# Altium schematic sheet Connectors_V2_1.SchDoc(Connectors_V2_1)
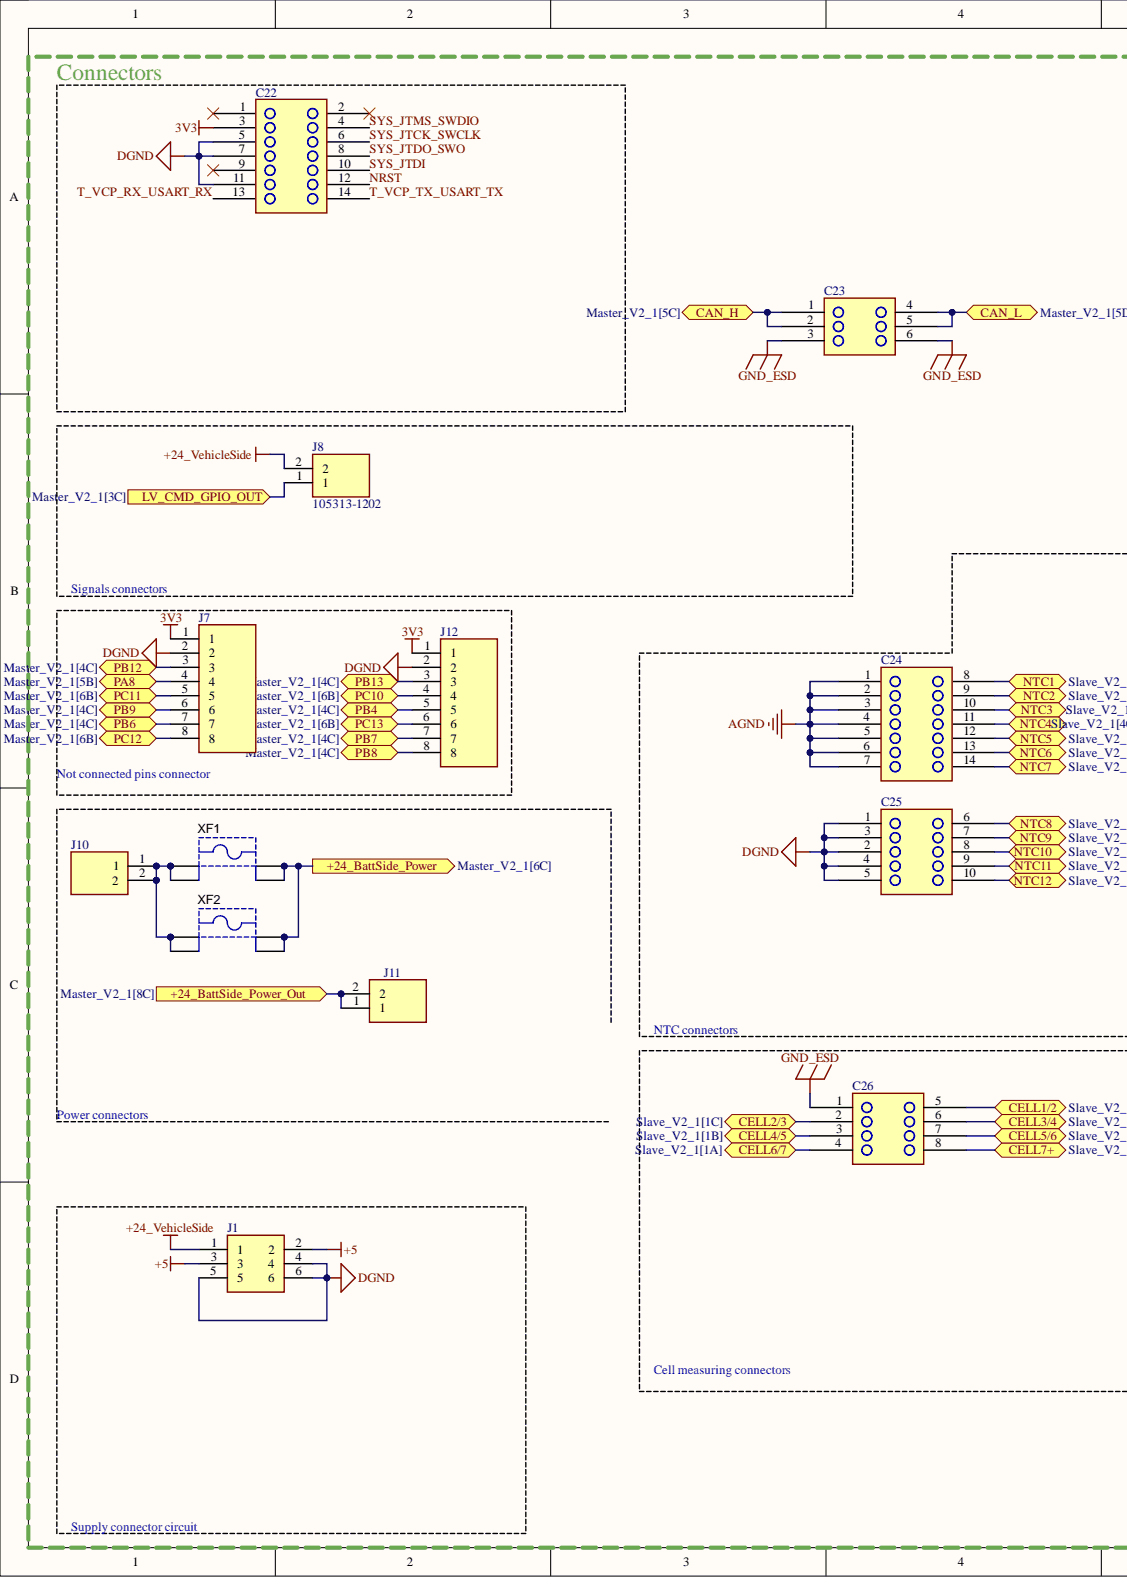
[diagram p0: Connectors_V2_1.SchDoc, left]
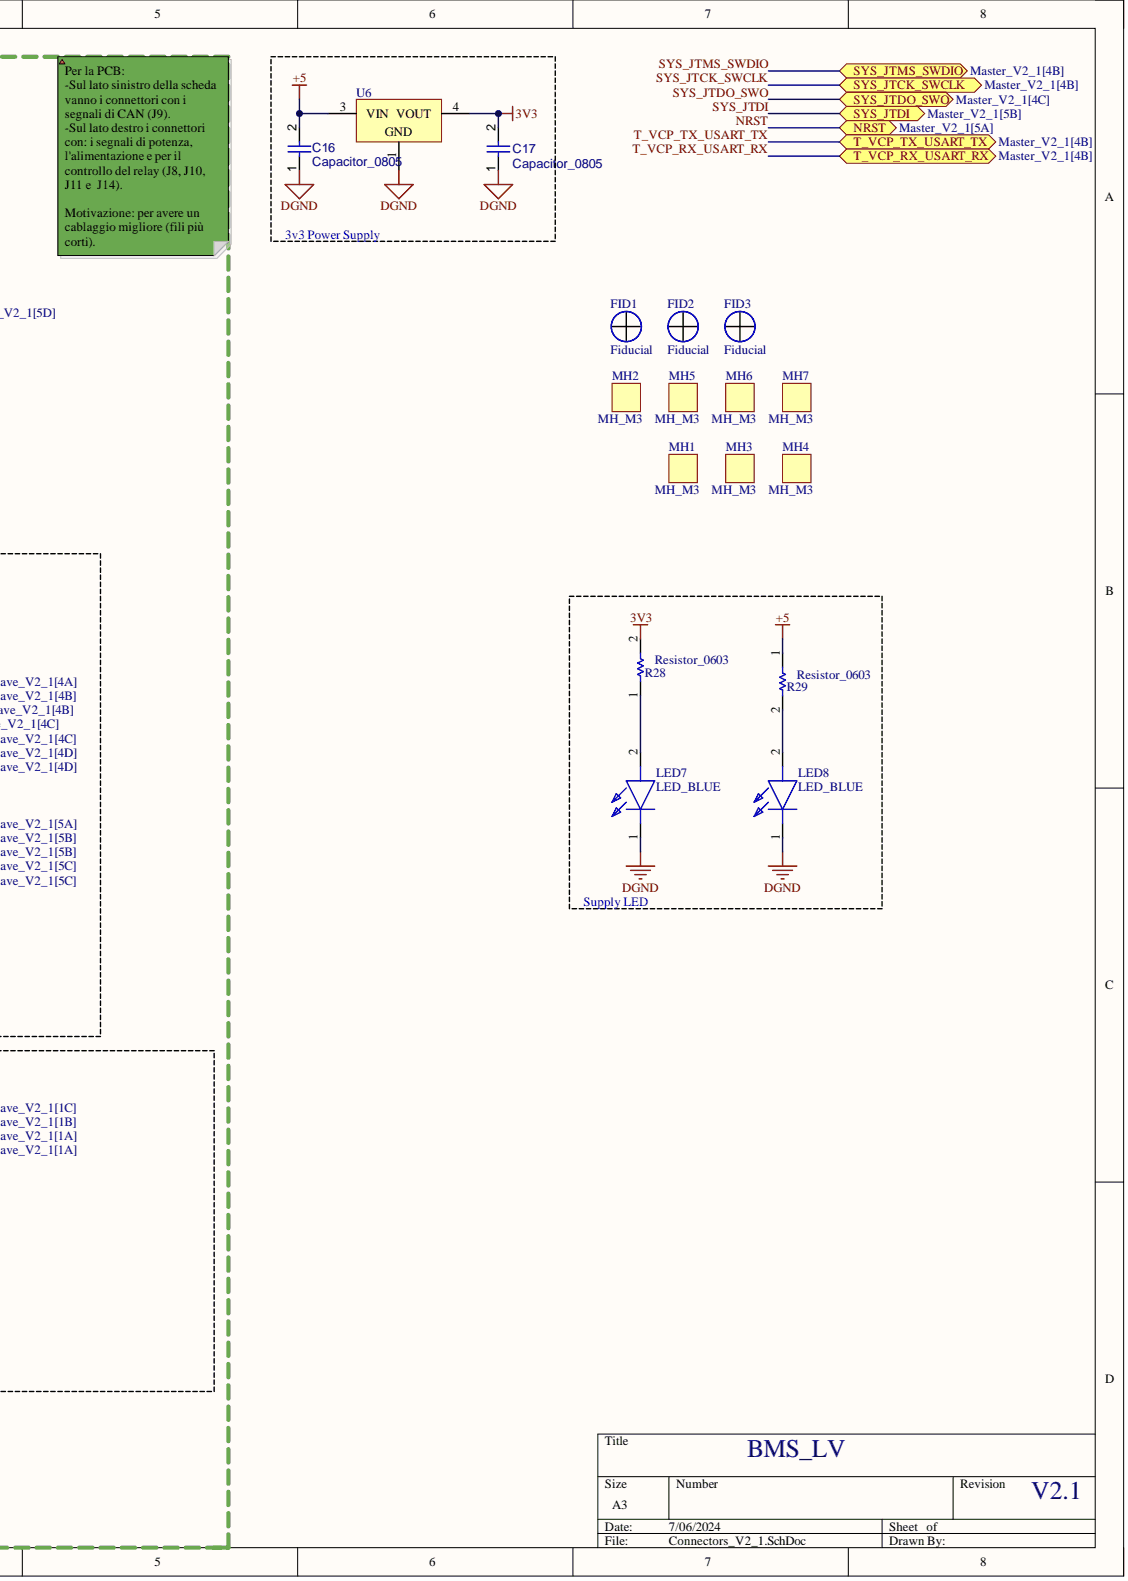
[diagram p1: Connectors_V2_1.SchDoc, right]

## Parts
C16  Capacitor_0805
C17  Capacitor_0805
C22  Samtec Header, 14 Pin, 1.27mm pitch  (value not drawn)
C23  NanoFit 6 Pin, Right Angle, Gold Plating  (value not drawn)
C24  NanoFit 14 Pin, Vertical,  Gold Plating  (value not drawn)
C25  105310-1210  (value not drawn)
C26  NanoFit 8 Pin, Vertical, Gold Plating105310-1208  (value not drawn)
FID1  Fiducial
FID2  Fiducial
FID3  Fiducial
J1  Molex Milli-Grid Series  (value not drawn)
J7  Headers & Wire Housings 8CKT VERT SR CON  (value not drawn)
J8  105313-1202
J10  200241-1222  (value not drawn)
J11  200241-1222  (value not drawn)
J12  Headers & Wire Housings 8CKT VERT SR CON  (value not drawn)
LED7  LED_BLUE
LED8  LED_BLUE
MH1  MH_M3
MH2  MH_M3
MH3  MH_M3
MH4  MH_M3
MH5  MH_M3
MH6  MH_M3
MH7  MH_M3
R28  Resistor_0603
R29  Resistor_0603
U6  LD1117DT33CTR  (value not drawn)
XF1  FUSE HOLDER, PCB MOUNT, 500VAC, 20A, ROHS  (value not drawn)
XF2  3557-2  (value not drawn)

## Ports
+24_BattSide_Power, +24_BattSide_Power_Out, CAN_H, CAN_L, CELL1/2, CELL2/3, CELL3/4, CELL4/5, CELL5/6, CELL6/7, CELL7+, LV_CMD_GPIO_OUT, NRST, NTC1, NTC2, NTC3, NTC4, NTC5, NTC6, NTC7, NTC8, NTC9, NTC10, NTC11, NTC12, PA8, PB4, PB6, PB7, PB8, PB9, PB12, PB13, PC10, PC11, PC12, PC13, SYS_JTCK_SWCLK, SYS_JTDI, SYS_JTDO_SWO, SYS_JTMS_SWDIO, T_VCP_RX_USART_RX, T_VCP_TX_USART_TX

## Nets
3V3: C17.2 C22.3 J7.1 J12.1 R28.2 U6.4
+5: C16.2 J1.2 J1.3 R29.1 U6.3
+24_VehicleSide: J1.1 J8.2
AGND: C24.1 C24.2 C24.3 C24.4 C24.5 C24.6 C24.7
DGND: C16.1 C17.1 C22.5 C22.7 C22.11 C25.1 C25.2 C25.3 C25.4 C25.5 J1.4 J1.5 J1.6 J7.2 J12.2 LED7.1 LED8.1 U6.1
GND_ESD: C23.3 C23.6 C26.1
+24_BattSide_Power: XF1.3 XF1.4 XF2.3 XF2.4 ; port +24_BattSide_Power
+24_BattSide_Power_Out: J11.1 J11.2 ; port +24_BattSide_Power_Out
CAN_H: C23.1 C23.2 ; port CAN_H
CAN_L: C23.4 C23.5 ; port CAN_L
CELL1/2: C26.5 ; port CELL1/2
CELL2/3: C26.2 ; port CELL2/3
CELL3/4: C26.6 ; port CELL3/4
CELL4/5: C26.3 ; port CELL4/5
CELL5/6: C26.7 ; port CELL5/6
CELL6/7: C26.4 ; port CELL6/7
CELL7+: C26.8 ; port CELL7+
LV_CMD_GPIO_OUT: J8.1 ; port LV_CMD_GPIO_OUT
NRST: C22.12 ; port NRST
NTC1: C24.8 ; port NTC1
NTC2: C24.9 ; port NTC2
NTC3: C24.10 ; port NTC3
NTC4: C24.11 ; port NTC4
NTC5: C24.12 ; port NTC5
NTC6: C24.13 ; port NTC6
NTC7: C24.14 ; port NTC7
NTC8: C25.6 ; port NTC8
NTC9: C25.7 ; port NTC9
NTC10: C25.8 ; port NTC10
NTC11: C25.9 ; port NTC11
NTC12: C25.10 ; port NTC12
PA8: J7.4 ; port PA8
PB4: J12.5 ; port PB4
PB6: J7.7 ; port PB6
PB7: J12.7 ; port PB7
PB8: J12.8 ; port PB8
PB9: J7.6 ; port PB9
PB12: J7.3 ; port PB12
PB13: J12.3 ; port PB13
PC10: J12.4 ; port PC10
PC11: J7.5 ; port PC11
PC12: J7.8 ; port PC12
PC13: J12.6 ; port PC13
SYS_JTCK_SWCLK: C22.6 ; port SYS_JTCK_SWCLK
SYS_JTDI: C22.10 ; port SYS_JTDI
SYS_JTDO_SWO: C22.8 ; port SYS_JTDO_SWO
SYS_JTMS_SWDIO: C22.4 ; port SYS_JTMS_SWDIO
T_VCP_RX_USART_RX: C22.13 ; port T_VCP_RX_USART_RX
T_VCP_TX_USART_TX: C22.14 ; port T_VCP_TX_USART_TX
NetJ10_1: J10.1 J10.2 XF1.1 XF1.2 XF2.1 XF2.2
NetLED7_2: LED7.2 R28.1
NetLED8_2: LED8.2 R29.2

Not connected: C22 1 2 9

## Library data of the parts
C16 C17 = CMP-001-00085-2: Footprint: 0805; Tech: SMD; Component Kind: Standard; ComponentLink1Description: Website; Package: 0805; Library Name: EMEA- Squadra Corse PoliTO-  Politecnico di Torino
C22 = CMP-00004-3: FTSH-107-01-L-DV-K-A; Component Kind: Standard; Library Name: EMEA- Squadra Corse PoliTO-  Politecnico di Torino
C23 = CMP-000003-3: 105314-1206; Component Kind: Standard; Library Name: EMEA- Squadra Corse PoliTO-  Politecnico di Torino
C24 = CMP-000001-6: 105310-1214; Component Kind: Standard; Library Name: EMEA- Squadra Corse PoliTO-  Politecnico di Torino
C25 = CMP-00003-4: NanoFit 10 Pin, Vertical, Gold Plating; Component Kind: Standard; Library Name: EMEA- Squadra Corse PoliTO-  Politecnico di Torino
C26 = CMP-00005-3: 105310-1208; Component Kind: Standard; Library Name: EMEA- Squadra Corse PoliTO-  Politecnico di Torino
FID1-FID3 = CMP-00028-00007-10: Component Kind: Standard; Library Name: EMEA- Squadra Corse PoliTO-  Politecnico di Torino
J1 = CMP-040-000015-3: 7910779107-7002; Tech: THT; Component Kind: Standard; Library Name: EMEA- Squadra Corse PoliTO-  Politecnico di Torino
J7 J12 = CMP-104-000000-2: 90147-1108; Manufacturer_Name: Molex; Geometry.Height: 8.7mm; Component Kind: Standard; Datasheet Link: https://www.molex.com/pdm_docs/sd/901471102_sd.pdf; Design Item ID: 90147-1108; Manufacturer_Part_Number: 90147-1108; Mouser Part Number: 538-90147-1108; Mouser Price/Stock: https://www.mouser.co.uk/ProductDetail/Molex/90147-1108?qs=QESXi79X%252BKqiwXr7kUdEeg%3D%3D; Source: 90147-1108.SchLib; Library Name: EMEA- Squadra Corse PoliTO-  Politecnico di Torino
J8 = CMP-003-000024-1: Connector; Manufacturer_Name: Molex; Component Kind: Standard; Datasheet Link: http://www.molex.com/pdm_docs/sd/1053131202_sd.pdf; Design Item ID: 105313-1202; Manufacturer_Part_Number: 105313-1202; Mouser Part Number: 538-105313-1202; Mouser Price/Stock: https://www.mouser.co.uk/ProductDetail/Molex/105313-1202?qs=PqFvFmEiOr6Yr1uRJ10a3A%3D%3D; Source: SamacSys.SchLib; Library Name: EMEA- Squadra Corse PoliTO-  Politecnico di Torino
J10 = CMP-008-000005-2: Current: 23.5 A; Tech: THT; Component Kind: Standard; Description: 200241-1222; Library Name: EMEA- Squadra Corse PoliTO-  Politecnico di Torino
J11 = CMP-008-000005-2: MEGAFIT; Current: 23.5 A; Tech: THT; Component Kind: Standard; Library Name: EMEA- Squadra Corse PoliTO-  Politecnico di Torino
LED7 LED8 = CMP-031-000016-1: APT2012LVBC_D; Manufacturer_Name: Kingbright; Manufacturer_Part_Number: APT2012LVBC/D; Arrow Part Number: APT2012LVBC/D; Arrow Price/Stock: https://www.arrow.com/en/products/apt2012lvbcd/kingbright; Component Kind: Standard; Datasheet Link: https://componentsearchengine.com/Datasheets/1/APT2012LVBC_D.pdf; Design Item ID: APT2012LVBC_D; Height: 0.85mm; Mouser Part Number: 604-APT2012LVBCD; Mouser Price/Stock: https://www.mouser.co.uk/ProductDetail/Kingbright/APT2012LVBC-D?qs=6oMev5NRZMGErJYT6KZLXg%3D%3D; Source: SamacSys.SchLib; Library Name: EMEA- Squadra Corse PoliTO-  Politecnico di Torino
MH1-MH7 = CMP-00028-00008-3: Mountinh Hole M3, 3,5 mm hole, 6,5 mm external; Component Kind: Standard; Library Name: EMEA- Squadra Corse PoliTO-  Politecnico di Torino
R28 R29 = CMP-009-00179-4: CRCW0603300RFKEA; Tech: SMD; Component Kind: Standard; ComponentLink1Description: Website; Package: 0603; Library Name: EMEA- Squadra Corse PoliTO-  Politecnico di Torino
U6 = CMP-0244-00089-1: Fixed Low Drop Positive Voltage Regulator, 3.3V, 3-Pin DPAK, Tape and Reel; Component Kind: Standard; ComponentLink1Description: Manufacturer URL; ComponentLink1URL: http://www.st.com; ComponentLink2Description: Datasheet; ComponentLink2URL: http://www.st.com/internet/com/TECHNICAL_RESOURCES/TECHNICAL_LITERATURE/DATASHEET/CD00000544.pdf; DatasheetVersion: 1/11/2011; Library Name: Altium Content Vault; PackageDescription: 3-Pin Transistor Outline Package 2.28 mm Pitch, 9.7 mm Lead Span (DPAK); PackageReference: TO-252; PackageVersion: 2011
XF1 = CMP-2000-05461-1: Manufacturer: Keystone-Electronics; Current Rating: 30A; For Use With/Related Products: ATC,ATO257,MINI897; Fuse Size: 0.43"Lx0.15"Wx0.64"H(10.92mmx3.81mmx16.25mm); Fuse Type: Blade; Fuseholder Type: Block; Number of Circuits: 1; Voltage: 500V; Category: CircuitProtection; Component Kind: Standard; Contact Finish: TinNickel; Contact Material: Brass; Datasheet URL: http://datasheet.octopart.com/3557-2-Keystone-datasheet-15715937.pdf; Datasheet Version: Apr-13; Description: FUSE HOLDER, PCB MOUNT, 500VAC, 20A, ROHS; Family: Fuseholders; Library Name: Altium Content Vault; Manufacturer URL: http://www.keyelco.com/; Mounting Type: PCB; Orientation: Vertical; Series: -; Termination Style: PCPin
XF2 = CMP-2000-05461-1: FUSE HOLDER, PCB MOUNT, 500VAC, 20A, ROHS; Manufacturer: Keystone-Electronics; Current Rating: 30A; For Use With/Related Products: ATC,ATO257,MINI897; Fuse Size: 0.43"Lx0.15"Wx0.64"H(10.92mmx3.81mmx16.25mm); Fuse Type: Blade; Fuseholder Type: Block; Number of Circuits: 1; Voltage: 500V; Category: CircuitProtection; Component Kind: Standard; Contact Finish: TinNickel; Contact Material: Brass; Datasheet URL: http://datasheet.octopart.com/3557-2-Keystone-datasheet-15715937.pdf; Datasheet Version: Apr-13; Family: Fuseholders; Library Name: Altium Content Vault; Manufacturer URL: http://www.keyelco.com/; Mounting Type: PCB; Orientation: Vertical; Series: -; Termination Style: PCPin
